# Altium schematic sheet THOutboard.SchDoc
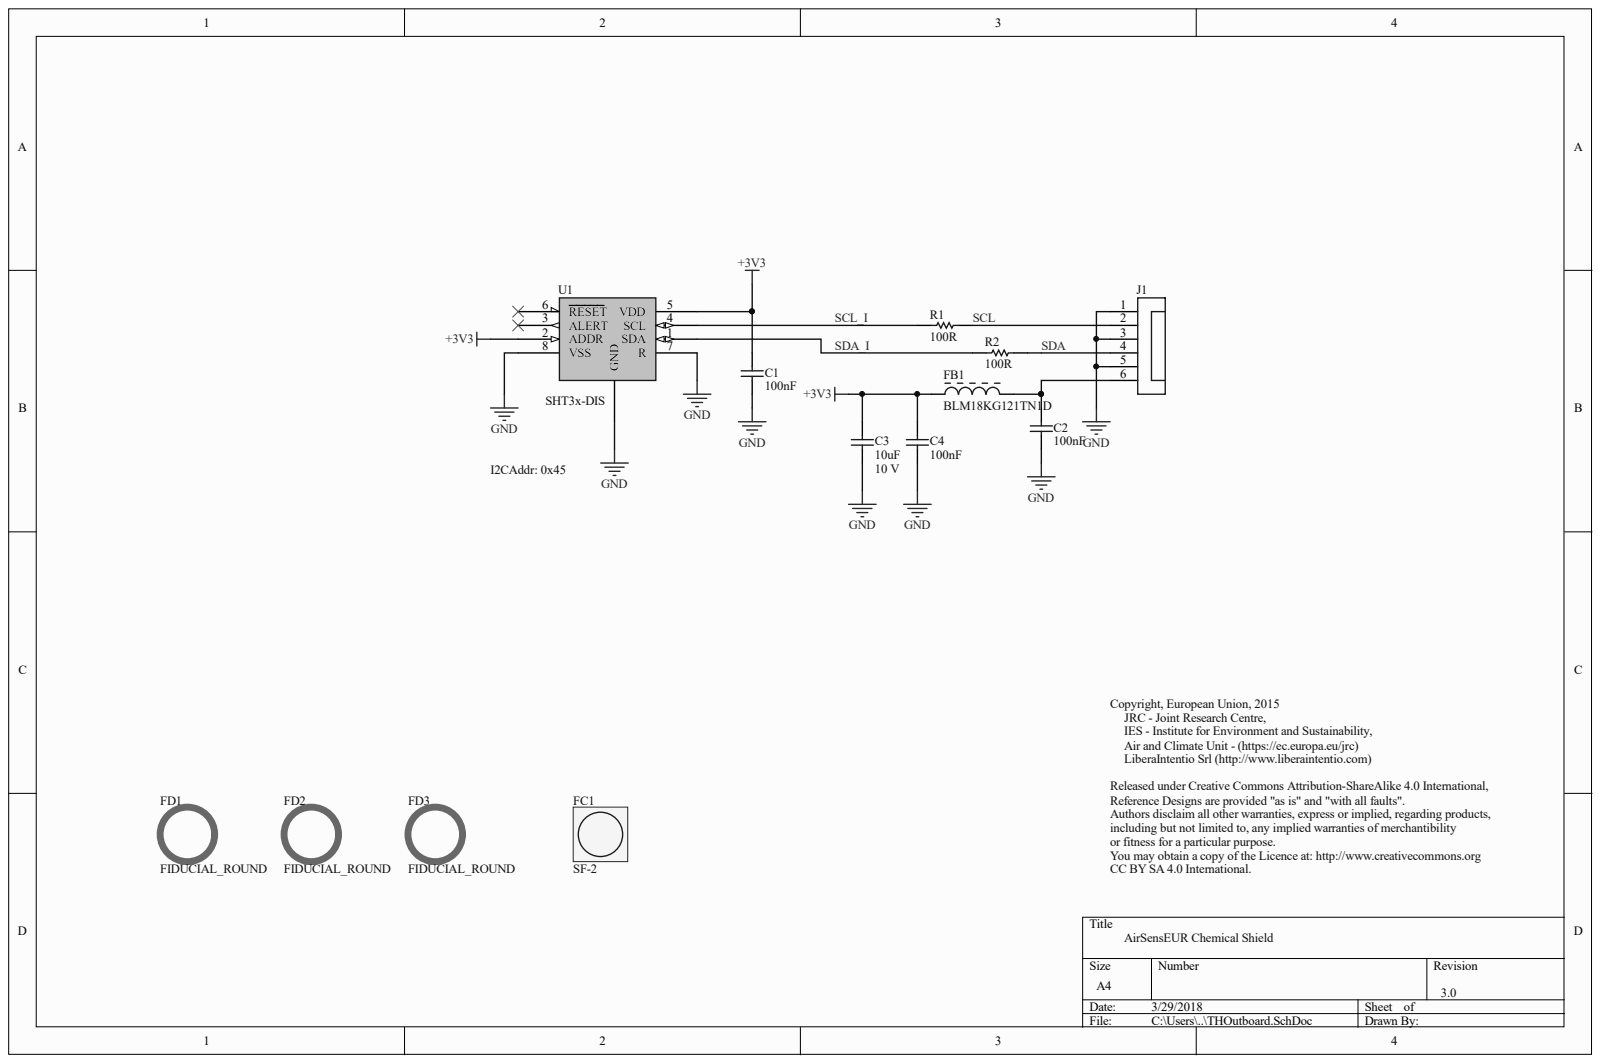
[diagram p0: THOutboard.SchDoc]

## Parts
C1  value 100nF  [CAPC0603(1608)100_L]  (hidden comment: CAP 100nF 25V 0603(1608))
C2  value 100nF  [CAPC0603(1608)100_L]  (hidden comment: CAP 100nF 25V 0603(1608))
C3  value 10uF  [CAPC0805(2012)100_L]  (hidden comment: CAP 10uF 10V ±10% 0805)
C4  value 100nF  [CAPC0603(1608)100_L]  (hidden comment: CAP 100nF 25V 0603(1608))
FB1  BLM18KG121TN1D  [MURA-BLM18SG-B40-CHIP-2_V2]
FC1  SF-2  [SF-2-ClipHolder]
FD1  FIDUCIAL_ROUND  [FIDUCIAL_ROUND]
FD2  FIDUCIAL_ROUND  [FIDUCIAL_ROUND]
FD3  FIDUCIAL_ROUND  [FIDUCIAL_ROUND]  (no BOM)
J1  Horizontal_Bottom Contact Low Profile_pitch 1mm_6pin  [686106183522]  (value not drawn)
R1  value 100R  [RESC0603(1608)_L]  (hidden comment: 100R 5% 0603(1608))
R2  value 100R  [RESC0603(1608)_L]  (hidden comment: 100R 5% 0603(1608))
U1  SHT3x-DIS  [SHT3x W]

## Nets
+3V3: C1.1 C3.1 C4.1 FB1.1 U1.2(ADDR) U1.5(VDD)
GND: C1.2 C2.2 C3.2 C4.2 J1.1 J1.3 J1.5 U1.7(R) U1.8(VSS) U1.diepad(GND)
SCL: J1.2 R1.1
SCL_I: R1.2 U1.4(SCL)
SDA: J1.4 R2.1
SDA_I: R2.2 U1.1(SDA)
NetC2_1: C2.1 FB1.2 J1.6

Not connected: U1 3(ALERT) 6(RESET)

## Library data of the parts
C1 C2 C4 = CMP-1035-02651-1: CAP 100nF 25V ±10% 0603 (1608 Metric) SMD; Case-EIA: 0603; Case-Metric: 1608; Component Kind: Standard; Library Name: Altium Content Vault; Max Thickness: 1 mm; Rated Voltage: 25 V; Supplier 1: TME; Supplier 2: Mouser; Supplier Part Number 1: 06033C104KAT2A; Supplier Part Number 2: 81-GRM39X104K25; Technology: SMT; Tolerance: ±10%
C3 = CMP-1036-01078-1: CAP 10uF 10V ±10% 0805 (2012 Metric); Case-EIA: 0805; Case-Metric: 2012; Component Kind: Standard; Library Name: Altium Content Vault; Max Thickness: 1 mm; Rated Voltage: 10 V; Supplier 1: Mouser; Supplier 2: TME; Supplier Part Number 1: 81-GRM21BR71A106KA3L; Supplier Part Number 2: GRM21BR61A106KE19L; Technology: SMT; Tolerance: ±10%
FB1 = CMP-0686-00602-2: Chip Ferrite Bead for Power Lines (Low DC Resistance Type), 120 Ohm, 3000 mA, -55 to 125 degC, 1.6 x 0.8 x 0.65 mm SMD, Tape and Reel; Manufacturer: Murata; DC Resistance: 0.025 Ohm Max.; Impedance (at 100 MHz): 120 Ohm +/- 25%; Rated Current: 3000 mA; Component Kind: Standard; ComponentLink1Description: Manufacturer URL; ComponentLink1URL: http://www.murata.com/; ComponentLink2Description: Datasheet; ComponentLink2URL: http://www.murata.com/products/catalog/pdf/c31e.pdf; ComponentLink3Description: Package Specification; ComponentLink3URL: http://www.murata.com/products/catalog/pdf/c31e.pdf; DatasheetVersion: 08/2013; Library Name: Altium Content Vault; Mounting Technology: Surface Mount; Operating Temperature Range: -55 to 125 degC; PackageDescription: 2-Pin Chip, Body 1.6 x 0.8 mm; PackageReference: BLM18_H650-2; Packing: Tape and Reel; PartNumber: BLM18KG121TN1D; Supplier 1: Mouser; Supplier 2: Digi-Key; Supplier 3: TME; Supplier Part Number 1: 81-BLM18KG121TN1D; Supplier Part Number 2: 490-5254-1-ND; Supplier Part Number 3: BLM18KG121TN1D
FC1 = SF-2: Filter Cap For SHT2x and SHT3x Humidity Sensors; Component Kind: Standard; Library Name: MyLibrary.IntLib; Supplier 1: Mouser; Supplier 2: Digi-Key; Supplier Part Number 1: 403-SF2; Supplier Part Number 2: 1649-1001-ND
FD1-FD3 = CMP-0486-00001-2: Fiducial - Round 1.5 mm Top-Bottom; Manufacturer: No Vendor; Library Name: Altium Content Vault; PartNumber: FIDUCIAL_ROUND
  FD1 FD2: Component Kind: Standard
J1 = 686106183522: ZIF Connectors WR-FPC, Horizontal, Bottom Contact Low Profile, pitch 1mm, 6pin; Contact Resistance: 30mOhm; Pin Number: 6; Rated Current: 0.8A; Component Kind: Standard; ComponentLink1Description: Manufacturer; ComponentLink1URL: http://www.we-online.de; ComponentLink2Description: Datasheet; ComponentLink2URL: http://katalog.we-online.de/em/datasheet/686106183522.pdf; Contact Design: Bottom Contact Low Profile; Library Name: WE-FPC_rev18a.IntLib; Mounting Technology: SMT; Operating Temperature: -25 to 85 degC; Orientation: Horizontal; Part Number: 686106183522; Pitch: 1mm; Published Date: Jan. 2018; Supplier 1: Digi-Key; Supplier 2: Mouser; Supplier Part Number 1: 732-11327-1-ND; Supplier Part Number 2: 710-686106183522; Publisher: Wurth Elektronik
R1 R2 = CMP-1012-00050-1: 100R 0.1W 5% 0603 (1608 Metric)  SMD; Case-EIA: 0603; Case-Metric: 1608; Component Kind: Standard; Library Name: Altium Content Vault; Power: 0.1 W; Supplier 1: TME; Supplier Part Number 1: RC0603JR-07100R; Technology: SMT; Tolerance: 5 %
U1 = SHT3x-DIS: Sensirion Humidity and Temperature Sensor; Component Kind: Standard; Library Name: SHT3x-DIS.SchLib; Supplier 1: Mouser; Supplier 2: Digi-Key; Supplier Part Number 1: 403-SHT31-DIS-B; Supplier Part Number 2: 1649-1011-1-ND
